# Altium schematic sheet DM0260_CCM.SchDoc(DM0260_CCM)
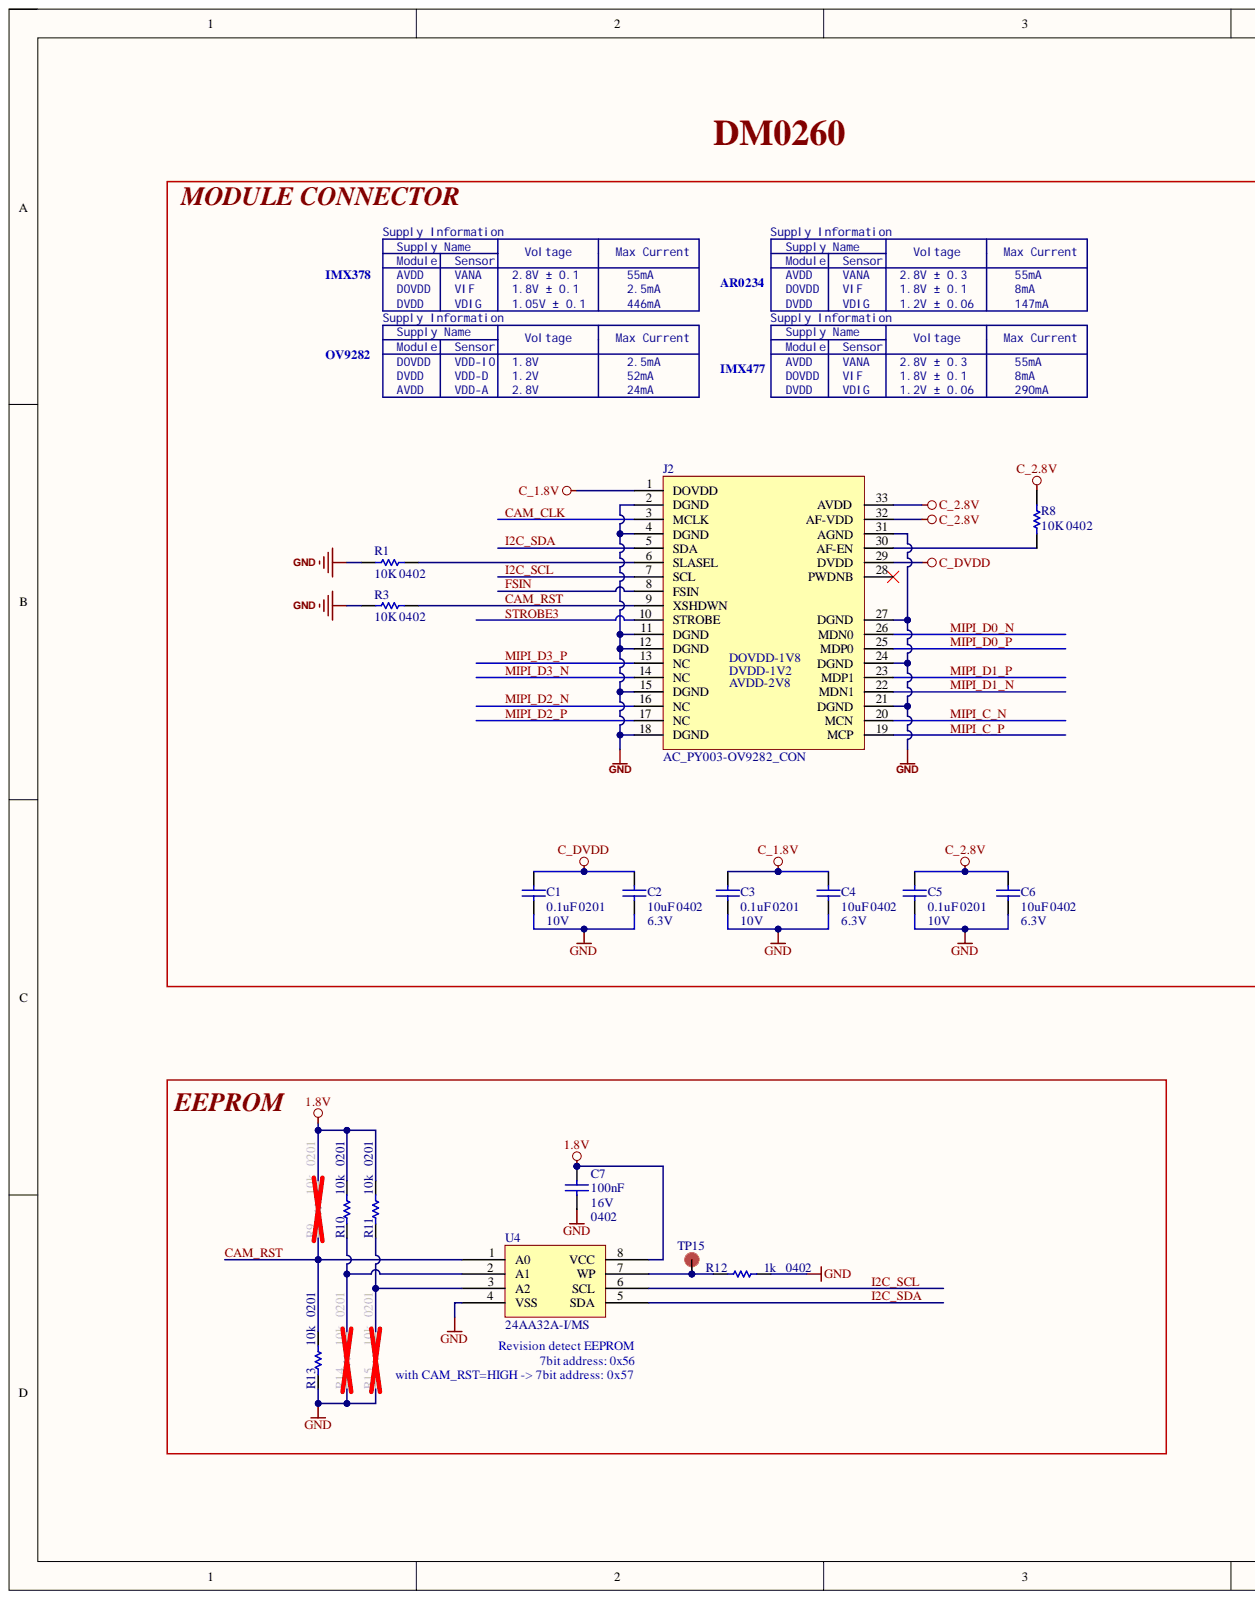
[diagram p0: DM0260_CCM.SchDoc, left]
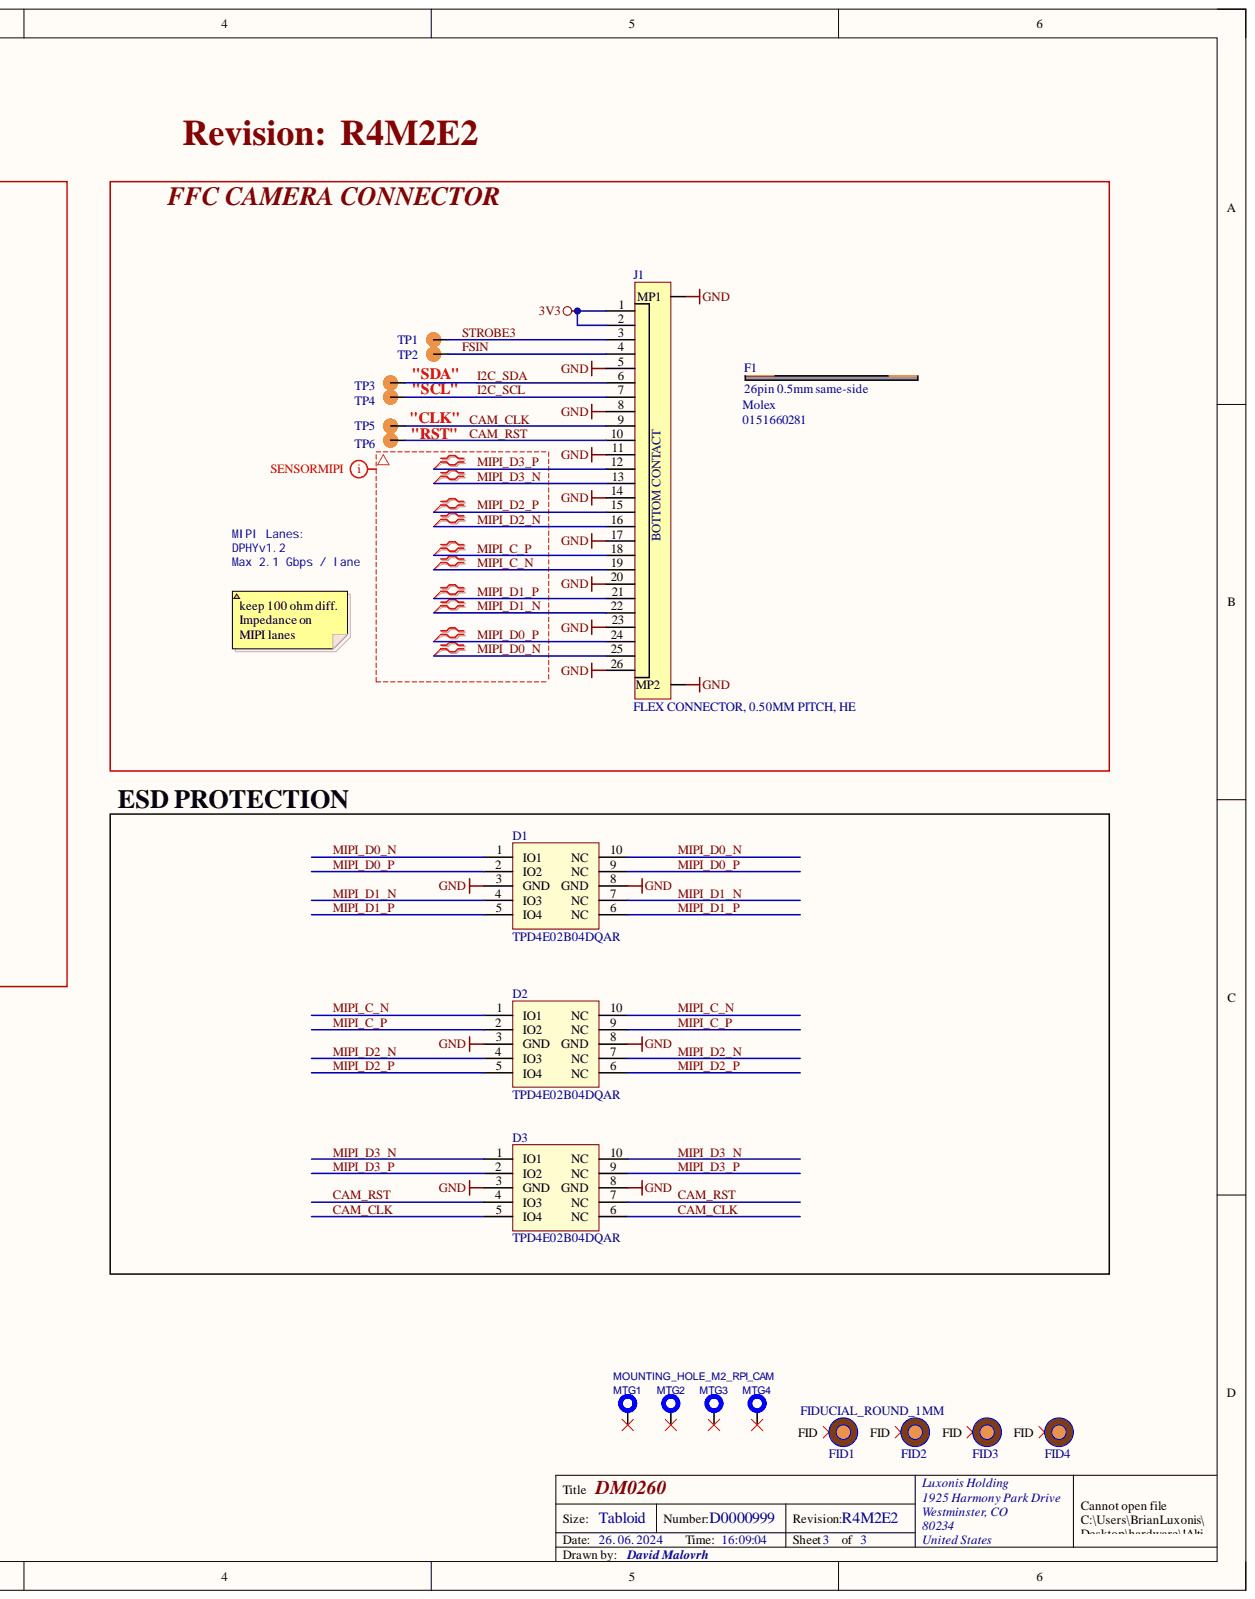
[diagram p1: DM0260_CCM.SchDoc, right]

## Parts
C1  0.1uF 0201  Voltage: 10V  [CAPC0201(0603)33_L]
C2  10uF 0402  Capacitance: 10uF  Voltage Rating: 6.3V  [CAPC0402(1005)70_L]
C3  0.1uF 0201  Voltage: 10V  [CAPC0201(0603)33_L]
C4  10uF 0402  Capacitance: 10uF  Voltage Rating: 6.3V  [CAPC0402(1005)70_L]
C5  0.1uF 0201  Voltage: 10V  [CAPC0201(0603)33_L]
C6  10uF 0402  Capacitance: 10uF  Case Code (Imperial): 0402  Voltage Rating: 6.3V  [CAPC0402(1005)70_L]
C7  value 100nF  Case/Package: 0402  Voltage Rating: 16V  [CAPC0402(1005)60_L]  (hidden comment: CL05B104KO5NFNC)
D1  TPD4E02B04DQAR  [TPD4E02B04DQAR]
D2  TPD4E02B04DQAR  [TPD4E02B04DQAR]
D3  TPD4E02B04DQAR  [TPD4E02B04DQAR]
F1  26pin 0.5mm same-side  Cable Pitch: 0.5mm  Manufacturer 1: Molex  (mechanical)
FID1  FIDUCIAL_ROUND_1MM  [FIDUCIAL_ROUND_1MM]  (no BOM)
FID2  FIDUCIAL_ROUND_1MM  [FIDUCIAL_ROUND_1MM]  (no BOM)
FID3  FIDUCIAL_ROUND_1MM  [FIDUCIAL_ROUND_1MM]  (no BOM)
FID4  FIDUCIAL_ROUND_1MM  [FIDUCIAL_ROUND_1MM]  (no BOM)
J1  FLEX CONNECTOR, 0.50MM PITCH, HE  [F32R-1A7H1-11026]
J2  AC_PY003-OV9282_CON  [AC-PY003-OV9282]
MTG1  MOUNTING_HOLE_M2_RPI_CAM  [MOUNTING_HOLE_M2_RPI_CAM]  (no BOM)
MTG2  MOUNTING_HOLE_M2_RPI_CAM  [MOUNTING_HOLE_M2_RPI_CAM]  (no BOM)
MTG3  MOUNTING_HOLE_M2_RPI_CAM  [MOUNTING_HOLE_M2_RPI_CAM]  (no BOM)
MTG4  MOUNTING_HOLE_M2_RPI_CAM  [MOUNTING_HOLE_M2_RPI_CAM]  (no BOM)
R1  10K 0402  Case Code (Imperial): 0402  [RESC0402(1005)_L]
R3  10K 0402  Case Code (Imperial): 0402  [RESC0402(1005)_L]
R8  10K 0402  Case Code (Imperial): 0402  [RESC0402(1005)_L]
R9  value 10k  Case/Package: 0201  [RESC0201(0603)_L]  (hidden comment: RMCF0201FT10K0)
R10  value 10k  Case/Package: 0201  [RESC0201(0603)_L]  (hidden comment: RMCF0201FT10K0)
R11  value 10k  Case/Package: 0201  [RESC0201(0603)_L]  (hidden comment: RMCF0201FT10K0)
R12  value 1k  Case/Package: 0402  [RESC0402(1005)_L]  (hidden comment: RC0402FR-071KL)
R13  value 10k  Case/Package: 0201  [RESC0201(0603)_L]  (hidden comment: RMCF0201FT10K0)
R14  value 10k  Case/Package: 0201  [RESC0201(0603)_L]  (hidden comment: RMCF0201FT10K0)
R15  value 10k  Case/Package: 0201  [RESC0201(0603)_L]  (hidden comment: RMCF0201FT10K0)
TP1  TP100_200_NS  [TP100_200_NS]  (value not drawn)  (no BOM)
TP2  TP100_200_NS  [TP100_200_NS]  (value not drawn)  (no BOM)
TP3  TP100_200_NS  [TP100_200_NS]  (value not drawn)  (no BOM)
TP4  TP100_200_NS  [TP100_200_NS]  (value not drawn)  (no BOM)
TP5  TP100_200_NS  [TP100_200_NS]  (value not drawn)  (no BOM)
TP6  TP100_200_NS  [TP100_200_NS]  (value not drawn)  (no BOM)
TP15  TEST_POINT  [TP075_150_NS]  (value not drawn)  (no BOM)
U4  24AA32A-I/MS  Memory Type: EEPROM  [24AA32A-I/MS]

## Nets
1.8V: C7.2 R9.2 R10.2 R11.2 U4.8(VCC)
3V3: J1.1 J1.2
C_1.8V: C3.2 C4.2 J2.1(DOVDD)
C_2.8V: C5.2 C6.2 J2.32(AF-VDD) J2.33(AVDD) R8.2
C_DVDD: C1.2 C2.2 J2.29(DVDD)
GND: C1.1 C2.1 C3.1 C4.1 C5.1 C6.1 C7.1 D1.3(GND) D1.8(GND) D2.3(GND) D2.8(GND) D3.3(GND) D3.8(GND) J1.5 J1.8 J1.11 J1.14 J1.17 J1.20 J1.23 J1.26 J1.MP1 J1.MP2 J2.2(DGND) J2.4(DGND) J2.11(DGND) J2.12(DGND) J2.15(DGND) J2.18(DGND) J2.21(DGND) J2.24(DGND) J2.27(DGND) J2.31(AGND) R1.1 R3.1 R12.1 R13.1 R14.1 R15.1 U4.4(VSS)
CAM_CLK: D3.5(IO4) D3.6(NC) J1.9 J2.3(MCLK) TP5.1
CAM_RST: D3.4(IO3) D3.7(NC) J1.10 J2.9(XSHDWN) R3.2 R9.1 R13.2 TP6.1 U4.1(A0)
FSIN: J1.4 J2.8(FSIN) TP2.1
I2C_SCL: J1.7 J2.7(SCL) TP4.1 U4.6(SCL)
I2C_SDA: J1.6 J2.5(SDA) TP3.1 U4.5(SDA)
MIPI_C_N: D2.1(IO1) D2.10(NC) J1.19 J2.20(MCN)
MIPI_C_P: D2.2(IO2) D2.9(NC) J1.18 J2.19(MCP)
MIPI_D0_N: D1.1(IO1) D1.10(NC) J1.25 J2.26(MDN0)
MIPI_D0_P: D1.2(IO2) D1.9(NC) J1.24 J2.25(MDP0)
MIPI_D1_N: D1.4(IO3) D1.7(NC) J1.22 J2.22(MDN1)
MIPI_D1_P: D1.5(IO4) D1.6(NC) J1.21 J2.23(MDP1)
MIPI_D2_N: D2.4(IO3) D2.7(NC) J1.16 J2.16(NC)
MIPI_D2_P: D2.5(IO4) D2.6(NC) J1.15 J2.17(NC)
MIPI_D3_N: D3.1(IO1) D3.10(NC) J1.13 J2.14(NC)
MIPI_D3_P: D3.2(IO2) D3.9(NC) J1.12 J2.13(NC)
STROBE3: J1.3 J2.10(STROBE) TP1.1
NetJ2_6: J2.6(SLASEL) R1.2
NetJ2_30: J2.30(AF-EN) R8.1
NetR10_1: R10.1 R14.2 U4.2(A1)
NetR11_1: R11.1 R15.2 U4.3(A2)
NetR12_2: R12.2 TP15.1 U4.7(WP)

Not connected: FID1 FID; FID2 FID; FID3 FID; FID4 FID; J2 28(PWDNB); MTG1 1; MTG2 1; MTG3 1; MTG4 1

## Library data of the parts
C1 C3 C5 = GRM033R61A104KE15D: CAP CER 0.1UF 10V X5R 0201; Manufacturer 1: Murata; Capacitance: 100nF; Tolerance: 10%; Case Code (Imperial): 0201; Case Code (Metric): 0603; Case/Package: 0201; Component Kind: Standard; ComponentLink1Description: https://datasheet.ciiva.com/26843/getdatasheetpartid-308656-26843739.pdf?src-supplier=Verical; ComponentLink1URL: https://datasheet.ciiva.com/26843/getdatasheetpartid-308656-26843739.pdf?src-supplier=Verical; ComponentLink2Description: https://datasheet.ciiva.com/5413/product-5413117.pdf?src-supplier=Mouser; ComponentLink2URL: https://datasheet.ciiva.com/5413/product-5413117.pdf?src-supplier=Mouser; ComponentLink3Description: https://datasheet.ciiva.com/6256/partnumbering-e-01-6256406.pdf?src-supplier=Digi-Key; ComponentLink3URL: https://datasheet.ciiva.com/6256/partnumbering-e-01-6256406.pdf?src-supplier=Digi-Key; ComponentLink4Description: https://datasheet.ciiva.com/6256/partnumbering-e-01-6256407.pdf?src-supplier=Digi-Key; ComponentLink4URL: https://datasheet.ciiva.com/6256/partnumbering-e-01-6256407.pdf?src-supplier=Digi-Key; ComponentLink5Description: https://datasheet.ciiva.com/6256/partnumbering-e-01-6256408.pdf?src-supplier=Digi-Key; ComponentLink5URL: https://datasheet.ciiva.com/6256/partnumbering-e-01-6256408.pdf?src-supplier=Digi-Key; Depth: 0.3mm; Dielectric: X5R; Height: 0.3mm; Lead Free: Lead Free; Length: 0.6mm; Manufacturer Part Number 1: GRM033R61A104KE15D; Max Operating Temperature: 85°C; Min Operating Temperature: -55°C; Mount: Surface Mount; Package Quantity: 1; Packaging: Tape and Reel; Pricing 1: 1=0.10000, 10=0.04200, 100=0.01860, 500=0.01330, 1000=0.01045, 2500=0.00950, 5000=0.00874 (USD); Radiation Hardening: No; REACH SVHC: No SVHC; RoHS: Yes; Stock 1: 45335312; Supplier 1: Digi-Key; Supplier Part Number 1: 490-5881-1-ND; Termination: SMD/SMT; Thickness: 0.013inch; Voltage Rating: 10V; Voltage Rating (DC): 10V; Weight: 6E-06oz; Width: 0.012inch; Library Name: LuxonisMaster.SchLib
C2 C4 C6 = GRM155R60J106ME15D: CAP CER 10UF 6.3V X5R 0402 20%; Manufacturer 1: Murata; Case Code (Metric): 1005; Case/Package: 0402; Component Kind: Standard; ComponentLink1Description: https://www.murata.com/~/media/webrenewal/support/library/catalog/products/capacitor/mlcc/c02e.ashx?la=en-us&src-supplier=Digi-Key; ComponentLink1URL: https://www.murata.com/~/media/webrenewal/support/library/catalog/products/capacitor/mlcc/c02e.ashx?la=en-us&src-supplier=Digi-Key; Dielectric: X5R; Length: 0.039inch; Manufacturer Part Number 1: GRM155R60J106ME15D; Max Operating Temperature: 85°C; Min Operating Temperature: -55°C; Mount: Surface Mount; Packaging: Tape and Reel; Pricing 1: 1=0.23000, 10=0.15700, 100=0.07950, 500=0.05442, 1000=0.04814, 2500=0.04605, 5000=0.04186 (USD); RoHS: Yes; Stock 1: 1839627; Supplier 1: Digi-Key; Supplier Part Number 1: 490-13238-1-ND; Thickness: 0.026inch; Tolerance: 20%; Voltage Rating (DC): 6.3V; Width: 0.02inch; Library Name: LuxonisMaster.SchLib
  C2 C4: Case Code (Imperial): 0402
C7 = CMP-108-000002-6: CAP CER 0.1UF 16V X7R 0402 10%; Manufacturer 1: Samsung; Component Kind: Standard; ComponentLink1Description: https://datasheet.ciiva.com/25767/cl05b104ko5nfnc-25767124.pdf?src-supplier=Digi-Key; ComponentLink1URL: https://datasheet.ciiva.com/25767/cl05b104ko5nfnc-25767124.pdf?src-supplier=Digi-Key; Depth: 0.5mm; Design Item ID: CL05B104KO5NFNC; Dielectric: X7R; Height: 0.5mm; Length: 1mm; Manufacturer Part Number 1: CL05B104KO5NFNC; Max Operating Temperature: 125°C; Min Operating Temperature: -55°C; Mounting Technology: SMT; Tolerance: 10%; Width: 0.02inch; Library Name: Luxonis LLC
D1-D3 = TPD4E02B04DQAR: TVS DIODE 3.6V 8.8V 10USON 8.8V (Typ) Clamp 5A (8/20µs) Ipp Tvs Diode Surface Mount 10-USON (2.5x1); Manufacturer 1: Texas Instruments; Interface: USB,HDMI; Min Breakdown Voltage: 5.5V; Number of Bidirectional Channels: 4; Peak Pulse Power: 17W; Power Line Protection: No; Clamping Voltage: 8.8V; Component Kind: Standard; ComponentLink1Description: http://www.ti.com/general/docs/lit/getliterature.tsp?genericPartNumber=TPD4E02B04&amp;&amp;fileType=pdf&src-supplier=Element14; ComponentLink1URL: http://www.ti.com/general/docs/lit/getliterature.tsp?genericPartNumber=TPD4E02B04&amp;&amp;fileType=pdf&src-supplier=Element14; ComponentLink2Description: http://www.ti.com/lit/ds/symlink/tpd4e02b04.pdf?src-supplier=Farnell; ComponentLink2URL: http://www.ti.com/lit/ds/symlink/tpd4e02b04.pdf?src-supplier=Farnell; ComponentLink3Description: http://www.ti.com/lit/ds/symlink/tpd4e02b04.pdf?src-supplier=Newark; ComponentLink3URL: http://www.ti.com/lit/ds/symlink/tpd4e02b04.pdf?src-supplier=Newark; ComponentLink4Description: http://www.ti.com/lit/ds/symlink/tpd4e02b04.pdf?src-supplier=Digi-Key; ComponentLink4URL: http://www.ti.com/lit/ds/symlink/tpd4e02b04.pdf?src-supplier=Digi-Key; Composition: Zener; Lead Free: Lead Free; Manufacturer Part Number 1: TPD4E02B04DQAR; Max Operating Temperature: 125°C; Min Operating Temperature: -40°C; Mount: Surface Mount; Number of Channels: 4; Number of Pins: 10; Operating Supply Voltage: 3.6V; Packaging: Cut Tape; Peak Pulse Current: 5A; Pricing 1: 1=0.69000, 10=0.60800, 100=0.46650, 500=0.36874, 1000=0.29499 (USD); REACH SVHC: No SVHC; Reverse Standoff Voltage: 3.6V; RoHS: Yes; Stock 1: 25615; Supplier 1: Digi-Key; Supplier Part Number 1: 296-43875-1-ND; Library Name: LuxonisMaster.SchLib
F1 = 0151660281: CABLE FFC 26POS 0.50MM 6"; Number of Conductors: 26; Pitch: 0.02inch; Wire/Cable Type: Flat Flex; ComponentLink1Description: https://datasheet.ciiva.com/10989/0151660281-cable-10989152.pdf?src-supplier=Avnet; ComponentLink1URL: https://datasheet.ciiva.com/10989/0151660281-cable-10989152.pdf?src-supplier=Avnet; ComponentLink2Description: https://datasheet.ciiva.com/2944/151660051-sd-2944673.pdf?src-supplier=Digi-Key; ComponentLink2URL: https://datasheet.ciiva.com/2944/151660051-sd-2944673.pdf?src-supplier=Digi-Key; Length: 6inch; Library Name: BG0249_R1M1E1.SchLib; Manufacturer Part Number 1: 0151660281; Number of Pins: 26; Package Quantity: 1000; Packaging: Bulk; Pricing 1: 1=2.21000, 10=2.01000, 25=1.88680, 50=1.80460, 100=1.72260, 250=1.55856, 500=1.43552, 1000=1.23045, 2500=1.14842 (USD); Radiation Hardening: No; RoHS: Yes; Stock 1: 118; Supplier 1: Digi-Key; Supplier 2: Mouser; Supplier Part Number 1: WM11334-ND; Supplier Part Number 2: 538-15166-0281
FID1-FID4 = FIDUCIAL: Fiducial with various available footprints; Library Name: LuxonisMaster.SchLib
J1 = F32R-1A7H1-11026: 26 Position FFC, FPC Connector Contacts, Bottom 0.020" (0.50mm) Surface Mount, Right Angle; Manufacturer 1: Amphenol ICC (FCI); Current Rating: 500mA; Number of Positions: 26; Pitch: 0.02inch; Voltage Rating: 50V; Category: Connectors; Component Kind: Standard; ComponentLink1Description: https://cdn.amphenol-icc.com/media/wysiwyg/files/drawing/f32r-f32j.pdf; ComponentLink1URL: https://cdn.amphenol-icc.com/media/wysiwyg/files/drawing/f32r-f32j.pdf; Contact Material: Bronze; Contact Plating: Tin; Flammability Rating: UL94 V-0; Library Name: DM1090FFC.SchLib; Manufacturer Part Number 1: F32R-1A7H1-11026; Max Operating Temperature: 105°C; Min Operating Temperature: -40°C; Mount: Surface Mount; Packaging: Tape and Reel; Pricing 1: 1=0.77, 10=0.721, 100=0.5529, 500=0.4808 (USD); RoHS: Yes; Stock 1: 1709; Supplier 1: Digi-Key; Supplier Part Number 1: 609-F32R-1A7H1-11026CT-ND; Termination: Solder
J2 = CMP-005-000036-1: FFC/FPC Connector, 33 Contact(s), 1 Row(s), Female, Right Angle, Surface Mount Terminal; Manufacturer 1: Hirose; Manufacturer Part Number 1: FH26W-33S-0.3SHW(60); Category: FFC / FPC; Component Kind: Standard; ComponentLink1Description: https://datasheet.ciiva.com:443/pdfs/VipMasterIC/IC/HIRO/HIRO-S-A0007913874/HIRO-S-A0008593114-1.pdf?src-supplier=IHS+Markit; ComponentLink1URL: https://datasheet.ciiva.com:443/pdfs/VipMasterIC/IC/HIRO/HIRO-S-A0007913874/HIRO-S-A0008593114-1.pdf?src-supplier=IHS+Markit; ComponentLink2Description: https://www.hirose.com/product/en/download_file/key_name/FH26/category/Catalog/doc_file_id/49355/?file_category_id=4&item_id=298&is_series=1&src-supplier=Digi-Key; ComponentLink2URL: https://www.hirose.com/product/en/download_file/key_name/FH26/category/Catalog/doc_file_id/49355/?file_category_id=4&item_id=298&is_series=1&src-supplier=Digi-Key; ComponentLink3Description: https://www.hirose.com/product/download/?distributor=digikey&amp;type=2d&amp;lang=en&amp;num=FH26W-13S-0.3SHW(60)&src-supplier=Digi-Key; ComponentLink3URL: https://www.hirose.com/product/download/?distributor=digikey&amp;type=2d&amp;lang=en&amp;num=FH26W-13S-0.3SHW(60)&src-supplier=Digi-Key; Design Item ID: AC_PY003-OV9282_CON; Pricing 1: 1=1,78000, 10=1,61700, 25=1,51800, 50=1,45200, 100=1,38600, 250=1,25400, 500=1,15500, 1000=0,99000, 2500=0,92400 (USD); RoHS: Yes; Stock 1: 627; Library Name: Luxonis LLC; Source: LuxonisMaster.SchLib
MTG1-MTG4 = MP_Mounting-Holes: A - Level: 0; B - Value: Not a Part; Description: MOUNTING_HOLE_M2_RPI_CAM; Library Name: LuxonisMaster.SchLib
R1 R3 R8 = RC0402FR-1310KL: RES SMD 10K OHM 1% 0402 1/16W Chip Resistor, 0402 [1005 Metric], 10 kohm, RC Series, 50 V, Thick Film, 62.5 mW; Manufacturer 1: Yageo; Power Rating: 62.5mW; Resistance: 10kR; Temperature Coefficient: 100ppm/°C; Voltage Rating: 50V; Case Code (Metric): 1005; Case/Package: 0402; Component Kind: Standard; ComponentLink1Description: https://datasheet.ciiva.com/16940/pyu-rc0402-51-rohs-l-6-16940293.pdf?src-supplier=Avnet+Europe; ComponentLink1URL: https://datasheet.ciiva.com/16940/pyu-rc0402-51-rohs-l-6-16940293.pdf?src-supplier=Avnet+Europe; ComponentLink2Description: https://datasheet.ciiva.com/28386/pyu-rc0402-51-rohs-l-6-28386855.pdf?src-supplier=Avnet+Europe; ComponentLink2URL: https://datasheet.ciiva.com/28386/pyu-rc0402-51-rohs-l-6-28386855.pdf?src-supplier=Avnet+Europe; ComponentLink3Description: https://datasheet.ciiva.com/16740/pyu-rc0402-51-rohs-l-6-r-16740602.pdf?src-supplier=Future+Electronics; ComponentLink3URL: https://datasheet.ciiva.com/16740/pyu-rc0402-51-rohs-l-6-r-16740602.pdf?src-supplier=Future+Electronics; ComponentLink4Description: https://datasheet.ciiva.com/8891/pyu-rc0402-51-rohs-l-6-8891339.pdf?src-supplier=Avnet; ComponentLink4URL: https://datasheet.ciiva.com/8891/pyu-rc0402-51-rohs-l-6-8891339.pdf?src-supplier=Avnet; ComponentLink5Description: https://datasheet.ciiva.com/5557/pyu-rc0402-51-rohs-l-6-r-5557997.pdf?src-supplier=Mouser; ComponentLink5URL: https://datasheet.ciiva.com/5557/pyu-rc0402-51-rohs-l-6-r-5557997.pdf?src-supplier=Mouser; ComponentLink6Description: https://datasheet.ciiva.com/7983/1018594-7983533.pdf?src-supplier=Element14; ComponentLink6URL: https://datasheet.ciiva.com/7983/1018594-7983533.pdf?src-supplier=Element14; Composition: Thick Film; Contact Plating: Tin,Matte,Lead; Depth: 0.5mm; Lead Free: Lead Free; Length: 1mm; Manufacturer Part Number 1: RC0402FR-1310KL; Max Operating Temperature: 155°C; Military Standard: Not; Min Operating Temperature: -55°C; Number of Pins: 2; Number of Terminations: 2; Packaging: Tape and Reel; Pricing 1: 1=0.10000, 25=0.01200, 100=0.00400, 250=0.00400, 500=0.00300, 1000=0.00300 (USD); Radiation Hardening: No; REACH SVHC: No SVHC; RoHS: Yes; Stock 1: 62239; Supplier 1: Newark; Supplier 2: Future Electronics; Supplier 3: Mouser; Supplier 4: Element14; Supplier Part Number 1: 67R9960; Supplier Part Number 2: RC0402FR-1310KL-CUTT; Supplier Part Number 3: 603-RC0402FR-1310KL; Supplier Part Number 4: 1799235; Termination: SMD/SMT; Tolerance: 1%; Voltage Rating (DC): 50V; Working Voltage: 50V; Library Name: LuxonisMaster.SchLib
R9-R11 R13-R15 = CMP-009-00340-3: RES SMD 10K OHM 1%  1/20W 0201; Manufacturer 1: Stackpole Electronics Inc; Power Rating: 50mW; Component Kind: Standard; Manufacturer Part Number 1: RMCF0201FT10K0; Tolerance: 1%; Library Name: Luxonis LLC
R12 = CMP-013-000004-10: RES SMD 1K OHM 1% 1/16W 0402; Manufacturer 1: Yageo; Power Rating: 62.5mW; Voltage Rating: 50V; Component Kind: Standard; Design Item ID: RC0402FR-071KL; Manufacturer Part Number 1: RC0402FR-071KL; Max Operating Temperature: 155°C; Min Operating Temperature: -55°C; Tolerance: 1%; Library Name: Luxonis LLC
TP1-TP6 = TEST_POINT: Library Name: LuxonisMaster.SchLib
TP15 = CMP-015-000000-2: Manufacturer Part Number 1: /; Design Item ID: TEST_POINT; Library Name: Luxonis LLC; Source: LuxonisMaster.SchLib
U4 = CMP-010-000010-1: IC EEPROM 32K I2C 400KHZ 8MSOP Memory IC 32Kb (4K x 8) I²C 400kHz 900ns 8-MSOP; Manufacturer 1: Microchip; Access Time: 3500ns; Interface: I2C,Serial,2-Wire; Max Supply Voltage: 5.5V; Min Supply Voltage: 1.7V; Nominal Supply Current: 3mA; Case/Package: MSOP; Component Kind: Standard; ComponentLink1Description: https://datasheet.ciiva.com/16309/filehandler-aspxddocname-en011853-16309808.pdf?src-supplier=Future+Electronics; ComponentLink1URL: https://datasheet.ciiva.com/16309/filehandler-aspxddocname-en011853-16309808.pdf?src-supplier=Future+Electronics; ComponentLink2Description: https://datasheet.ciiva.com/16621/21713l-16621382.pdf?src-supplier=Avnet+Europe; ComponentLink2URL: https://datasheet.ciiva.com/16621/21713l-16621382.pdf?src-supplier=Avnet+Europe; ComponentLink3Description: https://datasheet.ciiva.com/3185/21713l-3185209.pdf?src-supplier=Avnet; ComponentLink3URL: https://datasheet.ciiva.com/3185/21713l-3185209.pdf?src-supplier=Avnet; ComponentLink4Description: https://datasheet.ciiva.com/1154/1688094-1154810.pdf?src-supplier=Element14; ComponentLink4URL: https://datasheet.ciiva.com/1154/1688094-1154810.pdf?src-supplier=Element14; ComponentLink5Description: https://datasheet.ciiva.com/41454/001085239-da-01-en-ic-eeprom-32kbit-24aa32a-i-ms-msop-8-mcp-41454934.pdf?src-supplier=Conrad; ComponentLink5URL: https://datasheet.ciiva.com/41454/001085239-da-01-en-ic-eeprom-32kbit-24aa32a-i-ms-msop-8-mcp-41454934.pdf?src-supplier=Conrad; Contact Plating: Tin,Matte; Density: 32Kb; Design Item ID: 24AA32A-I/MS; Frequency: 400kHz; Height: 0.85mm; Lead Free: Lead Free; Length: 3mm; Manufacturer Part Number 1: 24AA32A-I/MS; Max Frequency: 400kHz; Max Operating Temperature: 85°C; Memory Size: 32Kb; Min Operating Temperature: -40°C; Mount: Surface Mount; Number of Pins: 8; Package Quantity: 100; Packaging: Rail/Tube; Pricing 1: 1=0.39000, 25=0.38120, 100=0.36050 (USD); Radiation Hardening: No; REACH SVHC: No SVHC; RoHS: Yes; Stock 1: 4213; Width: 3mm; Library Name: Luxonis LLC; Source: LuxonisMaster.SchLib
